# Altium schematic sheet 3_iip_quad_uart.SchDoc(3_iip_quad_uart)
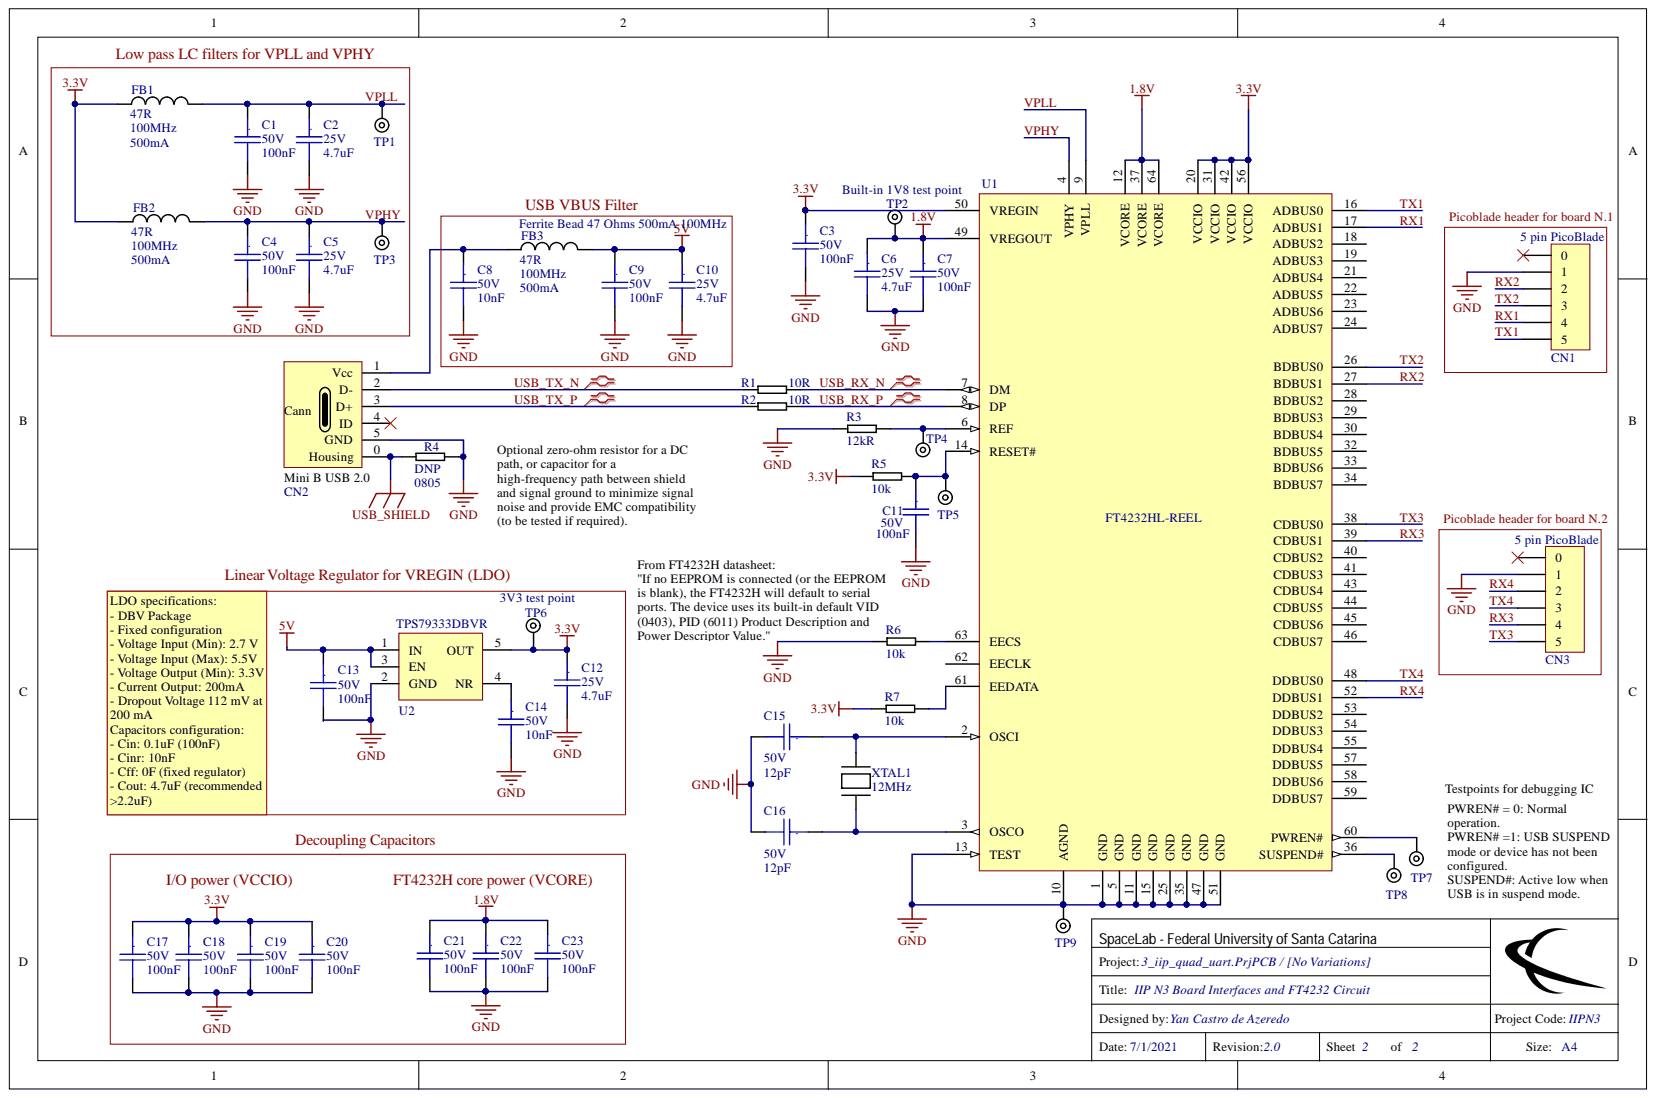
[diagram p0: 3_iip_quad_uart.SchDoc]

## Parts
C1  Voltage Rating (DC): 50V  Capacitance: 100nF  [C0603_MD]  (hidden comment: 0.1 uF, 0603 (1608 metric))
C2  Voltage Rating (DC): 25V  Capacitance: 4.7uF  [C0805_MD]  (hidden comment: 4.7 uF, 0802 (2012 metric))
C3  Voltage Rating (DC): 50V  Capacitance: 100nF  [C0603_MD]  (hidden comment: 0.1 uF, 0603 (1608 metric))
C4  Voltage Rating (DC): 50V  Capacitance: 100nF  [C0603_MD]  (hidden comment: 0.1 uF, 0603 (1608 metric))
C5  Voltage Rating (DC): 25V  Capacitance: 4.7uF  [C0805_MD]  (hidden comment: 4.7 uF, 0802 (2012 metric))
C6  Voltage Rating (DC): 25V  Capacitance: 4.7uF  [C0805_MD]  (hidden comment: 4.7 uF, 0802 (2012 metric))
C7  Voltage Rating (DC): 50V  Capacitance: 100nF  [C0603_MD]  (hidden comment: 0.1 uF, 0603 (1608 metric))
C8  Voltage Rating (DC): 50V  Capacitance: 10nF  [C0805_MD]  (hidden comment: 10000 pF, 0805 (2012 metric))
C9  Voltage Rating (DC): 50V  Capacitance: 100nF  [C0603_MD]  (hidden comment: 0.1 uF, 0603 (1608 metric))
C10  Voltage Rating (DC): 25V  Capacitance: 4.7uF  [C0805_MD]  (hidden comment: 4.7 uF, 0802 (2012 metric))
C11  Voltage Rating (DC): 50V  Capacitance: 100nF  [C0603_MD]  (hidden comment: 0.1 uF, 0603 (1608 metric))
C12  Voltage Rating (DC): 25V  Capacitance: 4.7uF  [C0805_MD]  (hidden comment: 4.7 uF, 0802 (2012 metric))
C13  Voltage Rating (DC): 50V  Capacitance: 100nF  [C0603_MD]  (hidden comment: 0.1 uF, 0603 (1608 metric))
C14  Voltage Rating (DC): 50V  Capacitance: 10nF  [C0805_MD]  (hidden comment: 10000 pF, 0805 (2012 metric))
C15  Voltage Rating (DC): 50V  Capacitance: 12pF  [C0603_MD]  (hidden comment: 12 pF, 0603 (1608 metric))
C16  Voltage Rating (DC): 50V  Capacitance: 12pF  [C0603_MD]  (hidden comment: 12 pF, 0603 (1608 metric))
C17  Voltage Rating (DC): 50V  Capacitance: 100nF  [C0603_MD]  (hidden comment: 0.1 uF, 0603 (1608 metric))
C18  Voltage Rating (DC): 50V  Capacitance: 100nF  [C0603_MD]  (hidden comment: 0.1 uF, 0603 (1608 metric))
C19  Voltage Rating (DC): 50V  Capacitance: 100nF  [C0603_MD]  (hidden comment: 0.1 uF, 0603 (1608 metric))
C20  Voltage Rating (DC): 50V  Capacitance: 100nF  [C0603_MD]  (hidden comment: 0.1 uF, 0603 (1608 metric))
C21  Voltage Rating (DC): 50V  Capacitance: 100nF  [C0603_MD]  (hidden comment: 0.1 uF, 0603 (1608 metric))
C22  Voltage Rating (DC): 50V  Capacitance: 100nF  [C0603_MD]  (hidden comment: 0.1 uF, 0603 (1608 metric))
C23  Voltage Rating (DC): 50V  Capacitance: 100nF  [C0603_MD]  (hidden comment: 0.1 uF, 0603 (1608 metric))
CN1  5 pin PicoBlade  [PICO BLADE 0533980571]
CN2  USB Standard: USB 2.0  [MINI USB B 180GRAUS WE]  (hidden comment: Vertical mini B USB 2.0 port)
CN3  5 pin PicoBlade  [PICO BLADE 0533980571]
FB1  Test Frequency: 100MHz  Impedance: 47R  [L0603]  (hidden comment: Ferrite Bead 47 Ohms 500mA 100MHz)
FB2  Test Frequency: 100MHz  Impedance: 47R  [L0603]  (hidden comment: Ferrite Bead 47 Ohms 500mA 100MHz)
FB3  Ferrite Bead 47 Ohms 500mA 100MHz  Test Frequency: 100MHz  Material: Ferrite  Impedance: 47R  [L0603]
R1  Resistance: 10R  [R0603_MD]  (hidden comment: RES 10 ohms (0603))
R2  Resistance: 10R  [R0603_MD]  (hidden comment: RES 10 ohms (0603))
R3  Resistance: 12kR  [R0805_MD]  (hidden comment: RES 12K ohms (0805))
R4  DNP  Case Code (Imperial): 0805  [R0805_MD]
R5  value 10k  [R0805_MD]  (hidden comment: 10k ohms, 0805 (2012 metric))
R6  value 10k  [R0805_MD]  (hidden comment: 10k ohms, 0805 (2012 metric))
R7  value 10k  [R0805_MD]  (hidden comment: 10k ohms, 0805 (2012 metric))
TP1  DNP  [TEST POINT PTH]  (value not drawn)  (no BOM)
TP2  DNP  [TEST POINT PTH]  (value not drawn)  (no BOM)
TP3  DNP  [TEST POINT PTH]  (value not drawn)  (no BOM)
TP4  DNP  [TEST POINT PTH]  (value not drawn)  (no BOM)
TP5  DNP  [TEST POINT PTH]  (value not drawn)  (no BOM)
TP6  DNP  [TEST POINT PTH]  (value not drawn)  (no BOM)
TP7  DNP  [TEST POINT PTH]  (value not drawn)  (no BOM)
TP8  DNP  [TEST POINT PTH]  (value not drawn)  (no BOM)
TP9  DNP  [TEST POINT PTH]  (value not drawn)  (no BOM)
U1  FT4232HL-REEL  [LQFP-64]
U2  TPS79333DBVR  [SOT23-5]
XTAL1  Frequency: 12MHz  [TXC 7A Series]  (hidden comment: 12.000MHz 12pF)

## Nets
1.8V: C6.1 C7.1 C21.1 C22.1 C23.1 TP2.1 U1.12(VCORE) U1.37(VCORE) U1.49(VREGOUT) U1.64(VCORE)
3.3V: C3.1 C12.1 C17.1 C18.1 C19.1 C20.1 FB1.1 FB2.1 R5.1 R7.1 TP6.1 U1.20(VCCIO) U1.31(VCCIO) U1.42(VCCIO) U1.50(VREGIN) U1.56(VCCIO) U2.5(OUT)
5V: C9.1 C10.1 C13.1 FB3.2 U2.1(IN) U2.3(EN)
GND: C1.2 C2.2 C3.2 C4.2 C5.2 C6.2 C7.2 C8.2 C9.2 C10.2 C11.2 C12.2 C13.2 C14.2 C15.2 C16.2 C17.2 C18.2 C19.2 C20.2 C21.2 C22.2 C23.2 CN1.1 CN2.5(GND) CN3.1 R3.1 R4.2 R6.1 TP9.1 U1.1(GND) U1.5(GND) U1.10(AGND) U1.11(GND) U1.13(TEST) U1.15(GND) U1.25(GND) U1.35(GND) U1.47(GND) U1.51(GND) U2.2(GND)
USB_SHIELD: CN2.0(Housing) R4.1
RX1: CN1.4 U1.17(ADBUS1)
RX2: CN1.2 U1.27(BDBUS1)
RX3: CN3.4 U1.39(CDBUS1)
RX4: CN3.2 U1.52(DDBUS1)
TX1: CN1.5 U1.16(ADBUS0)
TX2: CN1.3 U1.26(BDBUS0)
TX3: CN3.5 U1.38(CDBUS0)
TX4: CN3.3 U1.48(DDBUS0)
USB_RX_N: R1.2 U1.7(DM)
USB_RX_P: R2.2 U1.8(DP)
USB_TX_N: CN2.2(D-) R1.1
USB_TX_P: CN2.3(D+) R2.1
VPHY: C4.1 C5.1 FB2.2 TP3.1 U1.4(VPHY)
VPLL: C1.1 C2.1 FB1.2 TP1.1 U1.9(VPLL)
NetC8_1: C8.1 CN2.1(Vcc) FB3.1
NetC11_1: C11.1 R5.2 TP5.1 U1.14(RESET#)
NetC14_1: C14.1 U2.4(NR)
NetC15_1: C15.1 U1.2(OSCI) XTAL1.1
NetC16_1: C16.1 U1.3(OSCO) XTAL1.2
NetR3_2: R3.2 TP4.1 U1.6(REF)
NetR6_2: R6.2 U1.63(EECS)
NetR7_2: R7.2 U1.61(EEDATA)
NetTP7_1: TP7.1 U1.60(PWREN#)
NetTP8_1: TP8.1 U1.36(SUSPEND#)

Not connected: CN1 0; CN2 4(ID); CN3 0; U1 18(ADBUS2) 19(ADBUS3) 21(ADBUS4) 22(ADBUS5) 23(ADBUS6) 24(ADBUS7) 28(BDBUS2) 29(BDBUS3) 30(BDBUS4) 32(BDBUS5) 33(BDBUS6) 34(BDBUS7) 40(CDBUS2) 41(CDBUS3) 43(CDBUS4) 44(CDBUS5) 45(CDBUS6) 46(CDBUS7) 53(DDBUS2) 54(DDBUS3) 55(DDBUS4) 57(DDBUS5) 58(DDBUS6) 59(DDBUS7) 62(EECLK)

## Library data of the parts
C1 C3 C4 C7 C9 C11 C13 C17-C23 = C1608X7R1H104K080AA: TDK - C1608X7R1H104K080AA - SMD Multilayer Ceramic Capacitor, 0.1 µF, 50 V, 0603 [1608 Metric], ± 10%, X7R, C Series; Width: 0.8mm; Weight: 7.1E-05oz; Voltage Rating: 50V; Voltage: 50V; Tolerance: 10%; Thickness: 0.037inch; Termination: SMD/SMT; Supplier Part Number 2: 810-C1608X7R1H104K; Supplier Part Number 1: 445-1314-1-ND; Supplier 2: Mouser; Supplier 1: Digi-Key; Stock 2: 34512; Stock 1: 513759; REACH SVHC: No SVHC; Radiation Hardening: No; Pricing 2: 1=0.12, 10=0.088, 100=0.041, 500=0.029, 1000=0.024, 4000=0.017, 8000=0.016, 24000=0.013, 48000=0.011 (USD); Pricing 1: 1=0.12, 10=0.088, 100=0.0413, 500=0.02896, 1000=0.02397 (USD); Packaging: Tape and Reel; Package Quantity: 1; Number of Pins: 2; Mount: Surface Mount; Min Operating Temperature: -55°C; Max Operating Temperature: 125°C; Manufacturer Part Number: C1608X7R1H104K080AA; Manufacturer: TDK; Length: 1.6mm; Lead Free: Lead Free; Height: 0.8mm; Dielectric Material: Ceramic; Dielectric: X7R; Depth: 0.8mm; Composition: Ceramic; ComponentLink5URL: http://www.farnell.com/datasheets/1744655.pdf?src-supplier=Element14; ComponentLink5Description: http://www.farnell.com/datasheets/1744655.pdf?src-supplier=Element14; ComponentLink4URL: http://www.farnell.com/datasheets/2792994.pdf?src-supplier=Farnell; ComponentLink4Description: http://www.farnell.com/datasheets/2792994.pdf?src-supplier=Farnell; ComponentLink3URL: http://www.tdk.co.jp/tefe02/e412_c.pdf?src-supplier=Avnet+Europe; ComponentLink3Description: http://www.tdk.co.jp/tefe02/e412_c.pdf?src-supplier=Avnet+Europe; ComponentLink2URL: http://uk.rs-online.com/webdocs/13d1/0900766b813d1103.pdf?src-supplier=RSComponents; ComponentLink2Description: http://uk.rs-online.com/webdocs/13d1/0900766b813d1103.pdf?src-supplier=RSComponents; ComponentLink1URL: https://product.tdk.com/info/en/catalog/spec/mlccspec_commercial_general_midvoltage_en.pdf?src-supplier=Digi-Key; ComponentLink1Description: https://product.tdk.com/info/en/catalog/spec/mlccspec_commercial_general_midvoltage_en.pdf?src-supplier=Digi-Key; Component Kind: Standard; Case Code (Metric): 1608; Case Code (Imperial): 0603; Case/Package: 0603; Library Name: IB_floripasat2.SchLib
C2 C5 C6 C10 C12 = C2012X7R1E475K125AB: Ceramic Capacitor SMD Multilayer, 0805 [2012 Metric], 4.7 uF, 25 V,  10%, X7R, C Series; Manufacturer: TDK; Width: 0.049inch; Weight: 0.000194oz; Voltage Rating: 25V; Voltage: 25V; Tolerance: 10%; Thickness: 0.057inch; Termination: SMD/SMT; Supplier Part Number 2: 810-C2012X7R1E475K; Supplier Part Number 1: 445-5972-1-ND; Supplier 2: Mouser; Supplier 1: Digi-Key; Stock 2: 141636; Stock 1: 196988; REACH SVHC: No SVHC; Radiation Hardening: No; Pricing 2: 1=0.54, 10=0.382, 100=0.251, 500=0.186, 1000=0.158, 2000=0.121 (USD); Pricing 1: 1=0.48, 10=0.339, 100=0.223, 500=0.16518, 1000=0.1404 (USD); Packaging: Tape and Reel; Package Quantity: 2000; Mount: Surface Mount; Min Operating Temperature: -55°C; Max Operating Temperature: 125°C; Manufacturer Part Number: C2012X7R1E475K125AB; Length: 2mm; Lead Free: Lead Free; Height: 1.25mm; Dielectric Material: Ceramic; Dielectric: X7R; Depth: 1.25mm; Composition: Ceramic; ComponentLink4URL: http://www.tdk.co.jp/tefe02/e412_c.pdf?src-supplier=Avnet+Europe; ComponentLink4Description: http://www.tdk.co.jp/tefe02/e412_c.pdf?src-supplier=Avnet+Europe; ComponentLink3URL: http://www.farnell.com/datasheets/1796654.pdf?src-supplier=Newark; ComponentLink3Description: http://www.farnell.com/datasheets/1796654.pdf?src-supplier=Newark; ComponentLink2URL: https://product.tdk.com/info/en/catalog/spec/mlccspec_commercial_general_midvoltage_en.pdf?src-supplier=Digi-Key; ComponentLink2Description: https://product.tdk.com/info/en/catalog/spec/mlccspec_commercial_general_midvoltage_en.pdf?src-supplier=Digi-Key; ComponentLink1URL: http://www.mouser.com/ds/2/400/lcc_commercial_general_en-837201.pdf?src-supplier=Mouser; ComponentLink1Description: http://www.mouser.com/ds/2/400/lcc_commercial_general_en-837201.pdf?src-supplier=Mouser; Component Kind: Standard; Case Code (Metric): 2012; Case Code (Imperial): 0805; Case/Package: 0805; Library Name: IB_floripasat2.SchLib
C8 C14 = 08055C103KAT4A: AVX - 08055C103KAT4A - SMD Multilayer Ceramic Capacitor, 10000 pF, 50 V, 0805 [2012 Metric], &#177; 10%, X7R; Manufacturer: Kyocera AVX; Width: 1.25mm; Weight: 0.000194oz; Voltage Rating: 50V; Voltage: 50V; Tolerance: 10%; Termination: SMD/SMT; Supplier Part Number 2: 581-08055C103KAT4A; Supplier Part Number 1: 478-10687-1-ND; Supplier 2: Mouser; Supplier 1: Digi-Key; Stock 2: 88428; Stock 1: 515489; Radiation Hardening: No; Pricing 2: 1=0.12, 10=0.085, 100=0.04, 500=0.028, 1000=0.023, 2500=0.018 (USD); Pricing 1: 1=0.13, 10=0.094, 100=0.044, 500=0.03086, 1000=0.02555, 2500=0.02378, 5000=0.02176 (USD); Packaging: Tape and Reel; Number of Pins: 2; Mount: Surface Mount; Min Operating Temperature: -55°C; Max Operating Temperature: 125°C; Manufacturer Part Number: 08055C103KAT4A; Length: 2.01mm; Lead Free: Lead Free; Height: 0.94mm; Dielectric: X7R; Depth: 1.25mm; ComponentLink2URL: http://www.avx.com/docs/Catalogs/cx7r.pdf?src-supplier=Future+Electronics; ComponentLink2Description: http://www.avx.com/docs/Catalogs/cx7r.pdf?src-supplier=Future+Electronics; ComponentLink1URL: http://www.mouser.com/ds/2/40/X7RDielectric-777024.pdf?src-supplier=Mouser; ComponentLink1Description: http://www.mouser.com/ds/2/40/X7RDielectric-777024.pdf?src-supplier=Mouser; Component Kind: Standard; Case Code (Metric): 2012; Case Code (Imperial): 0805; Case/Package: 0805; Library Name: IB_floripasat2.SchLib
C15 C16 = CL10C120JB8NNNC: Cap Ceramic 12pF 50VDC C0G 5% SMD 0603 Paper T/R; Manufacturer: Samsung; Temperature Coefficient: 300ppm/°C; Width: 0.031inch; Voltage Rating: 50V; Voltage: 50V; Tolerance: 5%; Thickness: 0.035inch; Supplier Part Number 1: 1276-1254-1-ND; Supplier 1: Digi-Key; Stock 1: 64675; Radiation Hardening: No; Pricing 1: 1=0.1, 10=0.045, 100=0.0201, 500=0.01436, 1000=0.01128 (USD); Packaging: Tape and Reel; Package Quantity: 1; Mount: Surface Mount; Min Operating Temperature: -55°C; Max Operating Temperature: 125°C; Material: Ceramic; Manufacturer Part Number: CL10C120JB8NNNC; Length: 1.6mm; Lead Free: Lead Free; Height: 0.8mm; Dielectric: C0G; Depth: 0.8mm; Contact Plating: Tin; ComponentLink3URL: https://produktinfo.conrad.com/datenblaetter/450000-474999/457317-da-01-en-VS_KONDENSATOR_0603.pdf?src-supplier=Conrad; ComponentLink3Description: https://produktinfo.conrad.com/datenblaetter/450000-474999/457317-da-01-en-VS_KONDENSATOR_0603.pdf?src-supplier=Conrad; ComponentLink2URL: https://www.verical.com/server-webapp/html/getDatasheet?partID=640658&src-supplier=Verical; ComponentLink2Description: https://www.verical.com/server-webapp/html/getDatasheet?partID=640658&src-supplier=Verical; ComponentLink1URL: https://media.digikey.com/pdf/Data%20Sheets/Samsung%20PDFs/CL_Series_MLCC_ds.pdf?src-supplier=Digi-Key; ComponentLink1Description: https://media.digikey.com/pdf/Data%20Sheets/Samsung%20PDFs/CL_Series_MLCC_ds.pdf?src-supplier=Digi-Key; Component Kind: Standard; Case Code (Metric): 1608; Case Code (Imperial): 0603; Case/Package: 0603; Library Name: IB_floripasat2.SchLib
CN1 CN3 = 53398-0571: Wire-To-Board Connector, Vertical, PicoBlade 53398 Series, Surface Mount, Header, 5, 1.25 mm; Manufacturer: Molex; Working Voltage: 125V; Pitch: 1.25mm; Number of Positions: 5; Insulation Resistance: 100MR; Glow Wire Compliant: Non-compliant; Gender: Male; Current Rating: 1A; Contact Resistance: 20mR; Body Plating: Tin; Voltage Rating (DC): 125V; Voltage Rating (AC): 125V; Voltage Rating: 125V; Termination: Solder; Supplier Part Number 2: 538-53398-0571; Supplier Part Number 1: WM7609CT-ND; Supplier 2: Mouser; Supplier 1: Digi-Key; Stock 2: 35606; Stock 1: 427; REACH SVHC: Unknown; Radiation Hardening: No; Pricing 2: 1=1.32, 10=1.15, 100=0.999, 500=0.818, 1000=0.389, 2000=0.342 (USD); Pricing 1: 1=1.32, 10=1.159, 100=0.9999, 500=0.81814 (USD); Packaging: Tape and Reel; Package Quantity: 1000; Orientation: Vertical; Number of Rows: 1; Number of Contacts: 5; Number of Circuits: 5; Mount: Surface Mount; Min Operating Temperature: -40°C; Max Voltage Rating (AC): 125V; Max Operating Temperature: 85°C; Manufacturer Part Number: 53398-0571; Lead Pitch: 1.25mm; Lead Free: Lead Free; Housing Material: Nylon; Housing Color: Natural; Flammability Rating: UL94 V-0; Contact Plating: Tin; Contact Material: Bronze,Tin,Brass; Contact Gender: Male; ComponentLink8URL: http://www.mouser.com/ds/2/276/0533980571_PCB_HEADERS-146486.pdf?src-supplier=Mouser; ComponentLink8Description: http://www.mouser.com/ds/2/276/0533980571_PCB_HEADERS-146486.pdf?src-supplier=Mouser; ComponentLink7URL: http://www.farnell.com/datasheets/1626108.pdf?src-supplier=Element14; ComponentLink7Description: http://www.farnell.com/datasheets/1626108.pdf?src-supplier=Element14; ComponentLink6URL: http://www.farnell.com/datasheets/1867377.pdf?src-supplier=Element14; ComponentLink6Description: http://www.farnell.com/datasheets/1867377.pdf?src-supplier=Element14; ComponentLink5URL: http://uk.rs-online.com/webdocs/078f/0900766b8078fc92.pdf?src-supplier=RSComponents; ComponentLink5Description: http://uk.rs-online.com/webdocs/078f/0900766b8078fc92.pdf?src-supplier=RSComponents; ComponentLink4URL: http://www.farnell.com/datasheets/1632115.pdf?src-supplier=Element14; ComponentLink4Description: http://www.farnell.com/datasheets/1632115.pdf?src-supplier=Element14; ComponentLink3URL: https://www.distrelec.biz/Web/Downloads/46/_e/gf116833-116846_e.pdf?mime=application%2Fpdf&src-supplier=Distrelec; ComponentLink3Description: https://www.distrelec.biz/Web/Downloads/46/_e/gf116833-116846_e.pdf?mime=application%2Fpdf&src-supplier=Distrelec; ComponentLink2URL: http://www.farnell.com/datasheets/2697810.pdf?src-supplier=Newark; ComponentLink2Description: http://www.farnell.com/datasheets/2697810.pdf?src-supplier=Newark; ComponentLink1URL: http://www.molex.com/webdocs/datasheets/pdf/en-us/0533980571_PCB_HEADERS.pdf?src-supplier=Avnet+Europe; ComponentLink1Description: http://www.molex.com/webdocs/datasheets/pdf/en-us/0533980571_PCB_HEADERS.pdf?src-supplier=Avnet+Europe; Component Kind: Standard; Color: Natural; Library Name: IB_floripasat2.SchLib
CN2 = 651005136421: WURTH ELEKTRONIK - 651005136421 - Conector USB, Mini USB Tipo B, USB 2.0, Receptáculo, 5 Vías, Montaje de Agujero Pasante, Vertical; Manufacturer: Wurth Electronics; Voltage Rating: 30V; Number of Positions: 5; Number of Ports: 1; Insulation Resistance: 1GR; Gender: Receptacle; Current Rating: 1A; Connector Type: USB,Socket; Width: 12mm; Termination: Solder; Supplier Part Number 2: 710-651005136421; Supplier Part Number 1: 732-2735-ND; Supplier 2: Mouser; Supplier 1: Digi-Key; Stock 2: 2133; Stock 1: 2667; REACH SVHC: Unknown; Pricing 2: 1=2.97, 10=2.63, 25=2.63, 50=2.47, 100=2.22, 250=2.22 (USD); Pricing 1: 1=2.97, 10=2.621, 50=2.47, 100=2.218, 500=2.016 (USD); Packaging: Tray; Package Quantity: 100; Orientation: Vertical; Number of Contacts: 5; Mount: Through Hole,Vertical; Min Operating Temperature: -40°C; Max Operating Temperature: 105°C; Manufacturer Part Number: 651005136421; Length: 7.7mm; Lead Free: Lead Free; Features: Shielded; Contact Plating: Gold; Contact Material: Copper Alloy; ComponentLink4URL: http://www.produktinfo.conrad.com/datenblaetter/1000000-1099999/001088420-da-01-en-WR_COM_MINI_USB_TYP_B_651005136421.pdf?src-supplier=Conrad; ComponentLink4Description: http://www.produktinfo.conrad.com/datenblaetter/1000000-1099999/001088420-da-01-en-WR_COM_MINI_USB_TYP_B_651005136421.pdf?src-supplier=Conrad; ComponentLink3URL: http://www.farnell.com/datasheets/1644185.pdf?src-supplier=Element14; ComponentLink3Description: http://www.farnell.com/datasheets/1644185.pdf?src-supplier=Element14; ComponentLink2URL: http://documents.rs-components.com/techlib://itc_doclist_GB.htm?stockno=7480872&src-supplier=RSComponents; ComponentLink2Description: http://documents.rs-components.com/techlib://itc_doclist_GB.htm?stockno=7480872&src-supplier=RSComponents; ComponentLink1URL: http://katalog.we-online.de/kataloge/eican/media/pdf/651005136421.pdf?src-supplier=Mouser; ComponentLink1Description: http://katalog.we-online.de/kataloge/eican/media/pdf/651005136421.pdf?src-supplier=Mouser; Component Kind: Standard; Color: Black; Library Name: IB_floripasat2.SchLib
FB1-FB3 = 742792608: WURTH ELEKTRONIK   742792608   FERRITE BEAD, 0.1 OHM, 0.5A, 0603; Manufacturer: Wurth Electronics; Tolerance: 25%; Resistance: 100mR; Number of Lines: 1; Inductance: 1H; Width: 0.8mm; Weight: 0.000141oz; Termination: SMD/SMT; Supplier Part Number 2: 710-742792608; Supplier Part Number 1: 732-1596-1-ND; Supplier 2: Mouser; Supplier 1: Digi-Key; Stock 2: 6414; Stock 1: 969; REACH SVHC: Unknown; Pricing 2: 1=0.18, 10=0.172, 25=0.172, 50=0.168, 100=0.155, 250=0.155 (USD); Pricing 1: 1=0.17, 50=0.168, 100=0.155, 500=0.148, 1000=0.141, 2000=0.134 (USD); Packaging: Tape and Reel; Package Quantity: 1; Mount: Surface Mount; Min Operating Temperature: -55°C; Max Operating Temperature: 125°C; Max DC Current: 500mA; Max Current Rating: 500mA; Manufacturer Part Number: 742792608; Length: 1.6mm; Lead Free: Lead Free; Height: 0.8mm; Depth: 0.8mm; DC Resistance (DCR): 100mR; Current Rating: 500mA; Core Material: Ferrite; ComponentLink6URL: http://www.farnell.com/datasheets/2290671.pdf?src-supplier=Newark; ComponentLink6Description: http://www.farnell.com/datasheets/2290671.pdf?src-supplier=Newark; ComponentLink5URL: http://www.mouser.com/ds/2/445/742792608-538481.pdf?src-supplier=Mouser; ComponentLink5Description: http://www.mouser.com/ds/2/445/742792608-538481.pdf?src-supplier=Mouser; ComponentLink4URL: http://www.farnell.com/datasheets/1696033.pdf?src-supplier=Element14; ComponentLink4Description: http://www.farnell.com/datasheets/1696033.pdf?src-supplier=Element14; ComponentLink3URL: http://documents.rs-components.com/techlib://itc_doclist_GB.htm?stockno=6693986&src-supplier=RSComponents; ComponentLink3Description: http://documents.rs-components.com/techlib://itc_doclist_GB.htm?stockno=6693986&src-supplier=RSComponents; ComponentLink2URL: http://www.farnell.com/datasheets/2290671.pdf?src-supplier=Farnell; ComponentLink2Description: http://www.farnell.com/datasheets/2290671.pdf?src-supplier=Farnell; ComponentLink1URL: http://katalog.we-online.de/pbs/datasheet/742792608.pdf?src-supplier=Digi-Key; ComponentLink1Description: http://katalog.we-online.de/pbs/datasheet/742792608.pdf?src-supplier=Digi-Key; Component Kind: Standard; Case Code (Metric): 1608; Case Code (Imperial): 0603; Case/Package: SMD/SMT; Library Name: IB_floripasat2.SchLib
  FB1 FB2: Material: Ferrite
R1 R2 = RC0603FR-0710RL: YAGEO (PHYCOMP) - RC0603FR-0710RL - RES, THICK FILM, 10R, 1%, 0.1W, 0603; Voltage Rating (DC): 50V; Temperature Coefficient: 200ppm/°C; Power Rating: 100mW; Width: 0.8mm; Voltage Rating: 50V; Tolerance: 1%; Termination: SMD/SMT; Supplier Part Number 2: 603-RC0603FR-0710RL; Supplier Part Number 1: 311-10.0HRCT-ND; Supplier 2: Mouser; Supplier 1: Digi-Key; Stock 2: 267888; Stock 1: 9251104; REACH SVHC: No SVHC; Radiation Hardening: No; Pricing 2: 1=0.15, 10=0.036, 100=0.012, 1000=0.009, 5000=0.006, 10000=0.006 (USD); Pricing 1: 1=0.1, 10=0.046, 100=0.0187, 1000=0.0084, 2500=0.00729 (USD); Packaging: Tape and Reel; Number of Pins: 2; Min Operating Temperature: -55°C; Military Standard: Not; Max Operating Temperature: 155°C; Manufacturer Part Number: RC0603FR-0710RL; Manufacturer: Yageo; Length: 1.6mm; Lead Free: Lead Free; Height: 0.45mm; Depth: 0.8mm; Contact Plating: Tin,Matte; Composition: Thick Film; ComponentLink4URL: http://www.yageo.com/documents/recent/PYu-RC0603_51_RoHS_L_4.pdf?src-supplier=Avnet+Europe; ComponentLink4Description: http://www.yageo.com/documents/recent/PYu-RC0603_51_RoHS_L_4.pdf?src-supplier=Avnet+Europe; ComponentLink3URL: http://documents.rs-components.com/techlib://itc_doclist_GB.htm?stockno=5048984&src-supplier=RSComponents; ComponentLink3Description: http://documents.rs-components.com/techlib://itc_doclist_GB.htm?stockno=5048984&src-supplier=RSComponents; ComponentLink2URL: http://www.yageo.com/documents/recent/PYu-RC0603_51_RoHS_L_4.pdf?src-supplier=Avnet; ComponentLink2Description: http://www.yageo.com/documents/recent/PYu-RC0603_51_RoHS_L_4.pdf?src-supplier=Avnet; ComponentLink1URL: http://www.farnell.com/datasheets/1868787.pdf?src-supplier=Element14; ComponentLink1Description: http://www.farnell.com/datasheets/1868787.pdf?src-supplier=Element14; Component Kind: Standard; Case Code (Metric): 1608; Case Code (Imperial): 0603; Case/Package: 0603; Library Name: IB_floripasat2.SchLib
R3 = RMCF0805FT12K0: Resistor, SMT, 0805, 12K Ohm, +/-1%, +/-100ppm, Thk Film, T/R Marked; Manufacturer: Stackpole Electronics; Tolerance: 1%; Temperature Coefficient: 100ppm/°C; Power Rating: 125mW; Width: 0.049inch; Supplier Part Number 1: RMCF0805FT12K0CT-ND; Supplier 1: Digi-Key; Stock 1: 30304; Radiation Hardening: No; Pricing 1: 1=0.1, 10=0.026, 100=0.0104, 1000=0.00466, 2500=0.00405 (USD); Packaging: Tape and Reel; Number of Terminations: 2; Mount: Surface Mount; Min Operating Temperature: -55°C; Max Power Dissipation: 125mW; Max Operating Temperature: 155°C; Manufacturer Part Number: RMCF0805FT12K0; Length: 0.079inch; Lead Free: Lead Free; Height: 0.026inch; Features: Automotive AEC-Q200; Contact Plating: Tin,Matte; Composition: Thick Film; ComponentLink5URL: http://components.arrow.com/part/dataview/40964023S7542466N1174?src-supplier=Arrow; ComponentLink5Description: http://components.arrow.com/part/dataview/40964023S7542466N1174?src-supplier=Arrow; ComponentLink4URL: http://seielect.com/Catalog/SEI-RMCF_RMCP.pdf?src-supplier=Future+Electronics; ComponentLink4Description: http://seielect.com/Catalog/SEI-RMCF_RMCP.pdf?src-supplier=Future+Electronics; ComponentLink3URL: https://www.seielect.com/catalog/SEI-Packaging.pdf?src-supplier=Digi-Key; ComponentLink3Description: https://www.seielect.com/catalog/SEI-Packaging.pdf?src-supplier=Digi-Key; ComponentLink2URL: https://www.seielect.com/Catalog/SEI-RMCF_RMCP.pdf?src-supplier=Digi-Key; ComponentLink2Description: https://www.seielect.com/Catalog/SEI-RMCF_RMCP.pdf?src-supplier=Digi-Key; ComponentLink1URL: https://www.seielect.com/catalog/SEI-RMCF_RMCP.pdf?src-supplier=Avnet; ComponentLink1Description: https://www.seielect.com/catalog/SEI-RMCF_RMCP.pdf?src-supplier=Avnet; Component Kind: Standard; Case Code (Metric): 2012; Case Code (Imperial): 0805; Case/Package: 0805; Library Name: IB_floripasat2.SchLib
R4 = CRCW08050000Z0EA: VISHAY - CRCW08050000Z0EA - SMD Chip Resistor, Jumper, 0805 [2012 Metric], 0 ohm, CRCW e3 Series, 150 V, Thick Film, 125 mW; Voltage Rating (DC): 150V; Tolerance: 1%; Temperature Coefficient: 100ppm/°C; Resistance: 0mR; Power Rating: 125mW; Width: 1.25mm; Weight: 0.000194oz; Voltage Rating: 150V; Termination: SMD/SMT; Supplier Part Number 2: 71-CRCW0805-0-E3; Supplier Part Number 1: 541-0.0ACT-ND; Supplier 2: Mouser; Supplier 1: Digi-Key; Stock 2: 496700; Stock 1: 487981; REACH SVHC: Unknown; Radiation Hardening: No; Pricing 2: 1=0.1, 10=0.024, 100=0.01, 1000=0.007, 5000=0.004, 10000=0.004 (USD); Pricing 1: 1=0.1, 10=0.04, 25=0.0288, 50=0.022, 100=0.0162, 250=0.01236, 500=0.0099, 1000=0.00729 (USD); Packaging: Tape and Reel; Package Quantity: 1; Number of Terminations: 2; Min Operating Temperature: -55°C; Military Standard: Not; Max Power Dissipation: 125mW; Max Operating Temperature: 155°C; Manufacturer Part Number: CRCW08050000Z0EA; Manufacturer: Vishay; Length: 2mm; Lead Free: Lead Free; Height: 0.45mm; Features: Automotive AEC-Q200; Depth: 1.25mm; Contact Plating: Tin,Lead; Composition: Thick Film; ComponentLink8URL: http://www.farnell.com/datasheets/2310790.pdf?src-supplier=Element14; ComponentLink8Description: http://www.farnell.com/datasheets/2310790.pdf?src-supplier=Element14; ComponentLink7URL: http://www.vishay.com/docs/20035/dcrcwe3.pdf?src-supplier=Digi-Key; ComponentLink7Description: http://www.vishay.com/docs/20035/dcrcwe3.pdf?src-supplier=Digi-Key; ComponentLink6URL: http://www.vishay.com/docs/20035/dcrcwe3.pdf?src-supplier=Allied+Electronics; ComponentLink6Description: http://www.vishay.com/docs/20035/dcrcwe3.pdf?src-supplier=Allied+Electronics; ComponentLink5URL: http://www.vishay.com/docs/20035/dcrcwe3.pdf?src-supplier=Future+Electronics; ComponentLink5Description: http://www.vishay.com/docs/20035/dcrcwe3.pdf?src-supplier=Future+Electronics; ComponentLink4URL: http://uk.rs-online.com/webdocs/0d98/0900766b80d98b26.pdf?src-supplier=RSComponents; ComponentLink4Description: http://uk.rs-online.com/webdocs/0d98/0900766b80d98b26.pdf?src-supplier=RSComponents; ComponentLink3URL: http://www.vishay.com/docs/20035/dcrcwe3.pdf?src-supplier=Mouser; ComponentLink3Description: http://www.vishay.com/docs/20035/dcrcwe3.pdf?src-supplier=Mouser; ComponentLink2URL: http://www.vishay.com/docs/20035/dcrcwe3.pdf?src-supplier=Distrelec; ComponentLink2Description: http://www.vishay.com/docs/20035/dcrcwe3.pdf?src-supplier=Distrelec; ComponentLink1URL: http://www.vishay.com/docs/20035/dcrcwe3.pdf?src-supplier=Avnet+Europe; ComponentLink1Description: http://www.vishay.com/docs/20035/dcrcwe3.pdf?src-supplier=Avnet+Europe; Component Kind: Standard; Case Code (Metric): 2012; Case/Package: 0805; Library Name: IB_floripasat2.SchLib
R5-R7 = CRCW080510K0FKEA: RES 10.0K OHM 1/8W 1% 0805 SMD; Manufacturer: Vishay Dale; Voltage Rating (DC): 150V; Temperature Coefficient: 100ppm/°C; Resistance: 10kR; Power Rating: 125mW; Width: 1.25mm; Weight: 0.000194oz; Voltage Rating: 150V; Tolerance: 1%; Termination: SMD/SMT; Supplier Part Number 6: 541-10.0KCDKR-ND; Supplier Part Number 5: 1632457; Supplier Part Number 4: 70201192; Supplier Part Number 3: 541-10.0KCCT-ND; Supplier Part Number 2: 71-CRCW0805-10K-E3; Supplier Part Number 1: 52K9795; Supplier 6: Digi-Key; Supplier 5: Farnell; Supplier 4: Allied; Supplier 3: Digi-Key; Supplier 2: Mouser; Supplier 1: Newark; Stock 6: 1354479; Stock 2: 101022; REACH SVHC: Unknown; Radiation Hardening: No; Pricing 6: 1=0.1, 10=0.062, 100=0.0254, 1000=0.01142, 2500=0.00991 (USD); Pricing 2: 1=0.1, 10=0.062, 100=0.025, 1000=0.01, 5000=0.007, 10000=0.006, 25000=0.005 (USD); Power: 1/8W; Packaging: Tape and Reel; Package Quantity: 5000; Mount Type: SMD; Min Operating Temperature: -55°C; Military Standard: Not; Max Operating Temperature: 155°C; Manufacturer Part Number: CRCW080510K0FKEA; Length: 2mm; Lead Free: Lead Free; Height: 0.45mm; Depth: 1.25mm; Contact Plating: Tin; Composition: Thick Film; ComponentLink8URL: http://www.vishay.com/docs/20035/dcrcwe3.pdf?src-supplier=Future+Electronics; ComponentLink8Description: http://www.vishay.com/docs/20035/dcrcwe3.pdf?src-supplier=Future+Electronics; ComponentLink7URL: http://www.vishay.com/docs/20035/dcrcwe3.pdf?src-supplier=Avnet; ComponentLink7Description: http://www.vishay.com/docs/20035/dcrcwe3.pdf?src-supplier=Avnet; ComponentLink6URL: http://www.farnell.com/datasheets/2310790.pdf?src-supplier=Element14; ComponentLink6Description: http://www.farnell.com/datasheets/2310790.pdf?src-supplier=Element14; ComponentLink5URL: http://www.vishay.com/docs/20035/dcrcwe3.pdf?src-supplier=Avnet+Europe; ComponentLink5Description: http://www.vishay.com/docs/20035/dcrcwe3.pdf?src-supplier=Avnet+Europe; ComponentLink4URL: http://www.vishay.com/docs/20035/dcrcwe3.pdf?src-supplier=Avnet+Asia; ComponentLink4Description: http://www.vishay.com/docs/20035/dcrcwe3.pdf?src-supplier=Avnet+Asia; ComponentLink3URL: http://www.alliedelec.com/m/d/0c934d6939ccefa68dbe0b96d821c8cf.pdf?src-supplier=Allied+Electronics; ComponentLink3Description: http://www.alliedelec.com/m/d/0c934d6939ccefa68dbe0b96d821c8cf.pdf?src-supplier=Allied+Electronics; ComponentLink2URL: http://uk.rs-online.com/webdocs/0d98/0900766b80d98b26.pdf?src-supplier=RSComponents; ComponentLink2Description: http://uk.rs-online.com/webdocs/0d98/0900766b80d98b26.pdf?src-supplier=RSComponents; ComponentLink1URL: http://www.mouser.com/ds/2/427/dcrcwe3-109170.pdf?src-supplier=Mouser; ComponentLink1Description: http://www.mouser.com/ds/2/427/dcrcwe3-109170.pdf?src-supplier=Mouser; Component Kind: Standard; Case Code (Metric): 2012; Case Code (Imperial): 0805; Case/Package: 0805; Library Name: IB_floripasat2.SchLib
TP1-TP9 = Test Point PTH: TEST POINT PAD; Library Name: IB_floripasat2.SchLib
U1 = FT4232HL-REEL: FTDI - FT4232HL-REEL - USB-UART/MPSSE, 4232, QUAD, 64LQFP; Operating Supply Voltage: 3.3V; Operating Supply Current: 70mA; Number of Transceivers: 4; Number of Channels: 1; Min Supply Voltage: 1.62V; Max Supply Voltage: 3.63V; Interface: UART; ESD Protection: Yes; Data Rate: 480Mbps; Width: 10.1mm; Weight: 0.012088oz; Supplier Part Number 2: 895-FT4232HL; Supplier Part Number 1: 768-1026-1-ND; Supplier 2: Mouser; Supplier 1: Digi-Key; Stock 2: 21282; Stock 1: 18748; Radiation Hardening: No; Pricing 2: 1=8.3, 25=8.09, 50=7.46, 100=7.14, 500=6.88, 1000=6.08 (USD); Pricing 1: 1=8.3, 10=8.09, 50=7.46, 100=7.14, 500=6.88006 (USD); Packaging: Tape and Reel; Package Quantity: 1000; Number of Pins: 64; Mount: Surface Mount; Min Operating Temperature: -40°C; Max Operating Temperature: 85°C; Manufacturer Part Number: FT4232HL-REEL; Manufacturer: FTDI; Length: 10.1mm; Lead Free: Lead Free; Height: 1.4mm; Dual Supply Voltage: 3.3V; ComponentLink5URL: http://datasheet.iiic.cc/ftdi/FT4232HL-REEL.pdf?src-supplier=IIIC; ComponentLink5Description: http://datasheet.iiic.cc/ftdi/FT4232HL-REEL.pdf?src-supplier=IIIC; ComponentLink4URL: https://www.verical.com/server-webapp/html/getDatasheet?partID=643215&src-supplier=Verical; ComponentLink4Description: https://www.verical.com/server-webapp/html/getDatasheet?partID=643215&src-supplier=Verical; ComponentLink3URL: http://www.ftdichip.com/Support/Documents/DataSheets/ICs/DS_FT4232H.pdf?src-supplier=Future+Electronics; ComponentLink3Description: http://www.ftdichip.com/Support/Documents/DataSheets/ICs/DS_FT4232H.pdf?src-supplier=Future+Electronics; ComponentLink2URL: http://www.alliedelec.com/images/products/datasheets/bm/FTDI/70403916.pdf?src-supplier=Allied+Electronics; ComponentLink2Description: http://www.alliedelec.com/images/products/datasheets/bm/FTDI/70403916.pdf?src-supplier=Allied+Electronics; ComponentLink1URL: http://www.ftdichip.com/Support/Documents/AppNotes/AN_128_User_Guide_For_FT4232H_Factory%20test%20utility.pdf?src-supplier=Digi-Key; ComponentLink1Description: http://www.ftdichip.com/Support/Documents/AppNotes/AN_128_User_Guide_For_FT4232H_Factory%20test%20utility.pdf?src-supplier=Digi-Key; Component Kind: Standard; Case/Package: LQFP; Library Name: IB_floripasat2.SchLib
U2 = TPS79333DBVR: TEXAS INSTRUMENTS - TPS79333DBVR - IC, V REG, LINEAR, 0.2A, SOT-23-5; Quiescent Current: 200uA; Power Rating: 560mW; Polarity: Positive; Output Voltage Accuracy: 2%; Output Voltage: 3.3V; Output Type: Fixed; Output Current: 200mA; Number of Regulators: 1; Min Supply Voltage: 2.7V; Min Current Limit: 285mA; Max Supply Voltage: 5.5V; Input Bias Current: 170uA; Dropout Voltage: 102mV; Width: 1.6mm; Termination: SMD/SMT; Supplier Part Number 2: 595-TPS79333DBVR; Supplier Part Number 1: 296-12961-1-ND; Supplier 2: Mouser; Supplier 1: Digi-Key; Stock 2: 31707; Stock 1: 21565; REACH SVHC: No SVHC; Radiation Hardening: No; Pricing 2: 1=0.72, 10=0.6, 100=0.379, 1000=0.289, 2000=0.282, 3000=0.252, 9000=0.242, 48000=0.233 (USD); Pricing 1: 1=0.73, 10=0.64, 25=0.6016, 100=0.4559, 250=0.388, 500=0.3686, 1000=0.2813 (USD); Power Dissipation: 560mW; Packaging: Tape and Reel; Package Quantity: 1; Number of Pins: 5; Number of Outputs: 1; Nominal Output Voltage: 3.3V; Min Output Voltage: 3.3V; Min Operating Temperature: -40°C; Min Input Voltage: 2.7V; Max Power Dissipation: 560mW; Max Output Voltage: 3.3V; Max Output Current: 200mA; Max Operating Temperature: 125°C; Max Input Voltage: 5.5V; Manufacturer Part Number: TPS79333DBVR; Manufacturer: Texas Instruments; Length: 2.9mm; Lead Free: Lead Free; Height: 1.15mm; Current Rating: 200mA; ComponentLink2URL: http://www.jameco.com/Jameco/Products/ProdDS/1123416.pdf?src-supplier=Jameco; ComponentLink2Description: http://www.jameco.com/Jameco/Products/ProdDS/1123416.pdf?src-supplier=Jameco; ComponentLink1URL: http://www.ti.com/general/docs/lit/getliterature.tsp?genericPartNumber=TPS793&amp;&amp;fileType=pdf&src-supplier=Farnell; ComponentLink1Description: http://www.ti.com/general/docs/lit/getliterature.tsp?genericPartNumber=TPS793&amp;&amp;fileType=pdf&src-supplier=Farnell; Component Kind: Standard; Case/Package: SOT-23; Accuracy: 2%; Library Name: IB_floripasat2.SchLib
XTAL1 = AA-12.000MAGE-T: Crystals 12.000MHz 30ppm 12pF -40C to 85C; Manufacturer: TXC; Frequency Stability: 50ppm; ESR (Equivalent Series Resistance): 100R; Width: 3.2mm; Tolerance: 30ppm; Termination: SMD/SMT; Supplier Part Number 2: 717-AA-12.000MAGE-T; Supplier Part Number 1: 887-1656-1-ND; Supplier 2: Mouser; Supplier 1: Digi-Key; Stock 2: 4856; Stock 1: 2398; REACH SVHC: No SVHC; Ratings: AEC-Q200; Pricing 2: 1=0.83, 10=0.734, 50=0.685, 100=0.606, 500=0.574, 1000=0.435 (USD); Pricing 1: 1=0.83, 10=0.734, 50=0.6858, 100=0.6061, 500=0.5742 (USD); Packaging: Tape and Reel; Package Quantity: 1000; Number of Pins: 2; Mount: Surface Mount; Min Operating Temperature: -40°C; Max Operating Temperature: 85°C; Manufacturer Part Number: AA-12.000MAGE-T; Length: 0.197inch; Height: 0.053inch; Frequency Tolerance: 30ppm; ComponentLink2URL: http://www.farnell.com/datasheets/1811860.pdf?src-supplier=Element14; ComponentLink2Description: http://www.farnell.com/datasheets/1811860.pdf?src-supplier=Element14; ComponentLink1URL: http://www.txccrystal.com/images/pdf/number-crystal-automotive.pdf?src-supplier=Digi-Key; ComponentLink1Description: http://www.txccrystal.com/images/pdf/number-crystal-automotive.pdf?src-supplier=Digi-Key; Component Kind: Standard; Case/Package: SMD/SMT; Library Name: IB_floripasat2.SchLib
